# Altium schematic sheet MCU - Motor Control.SchDoc
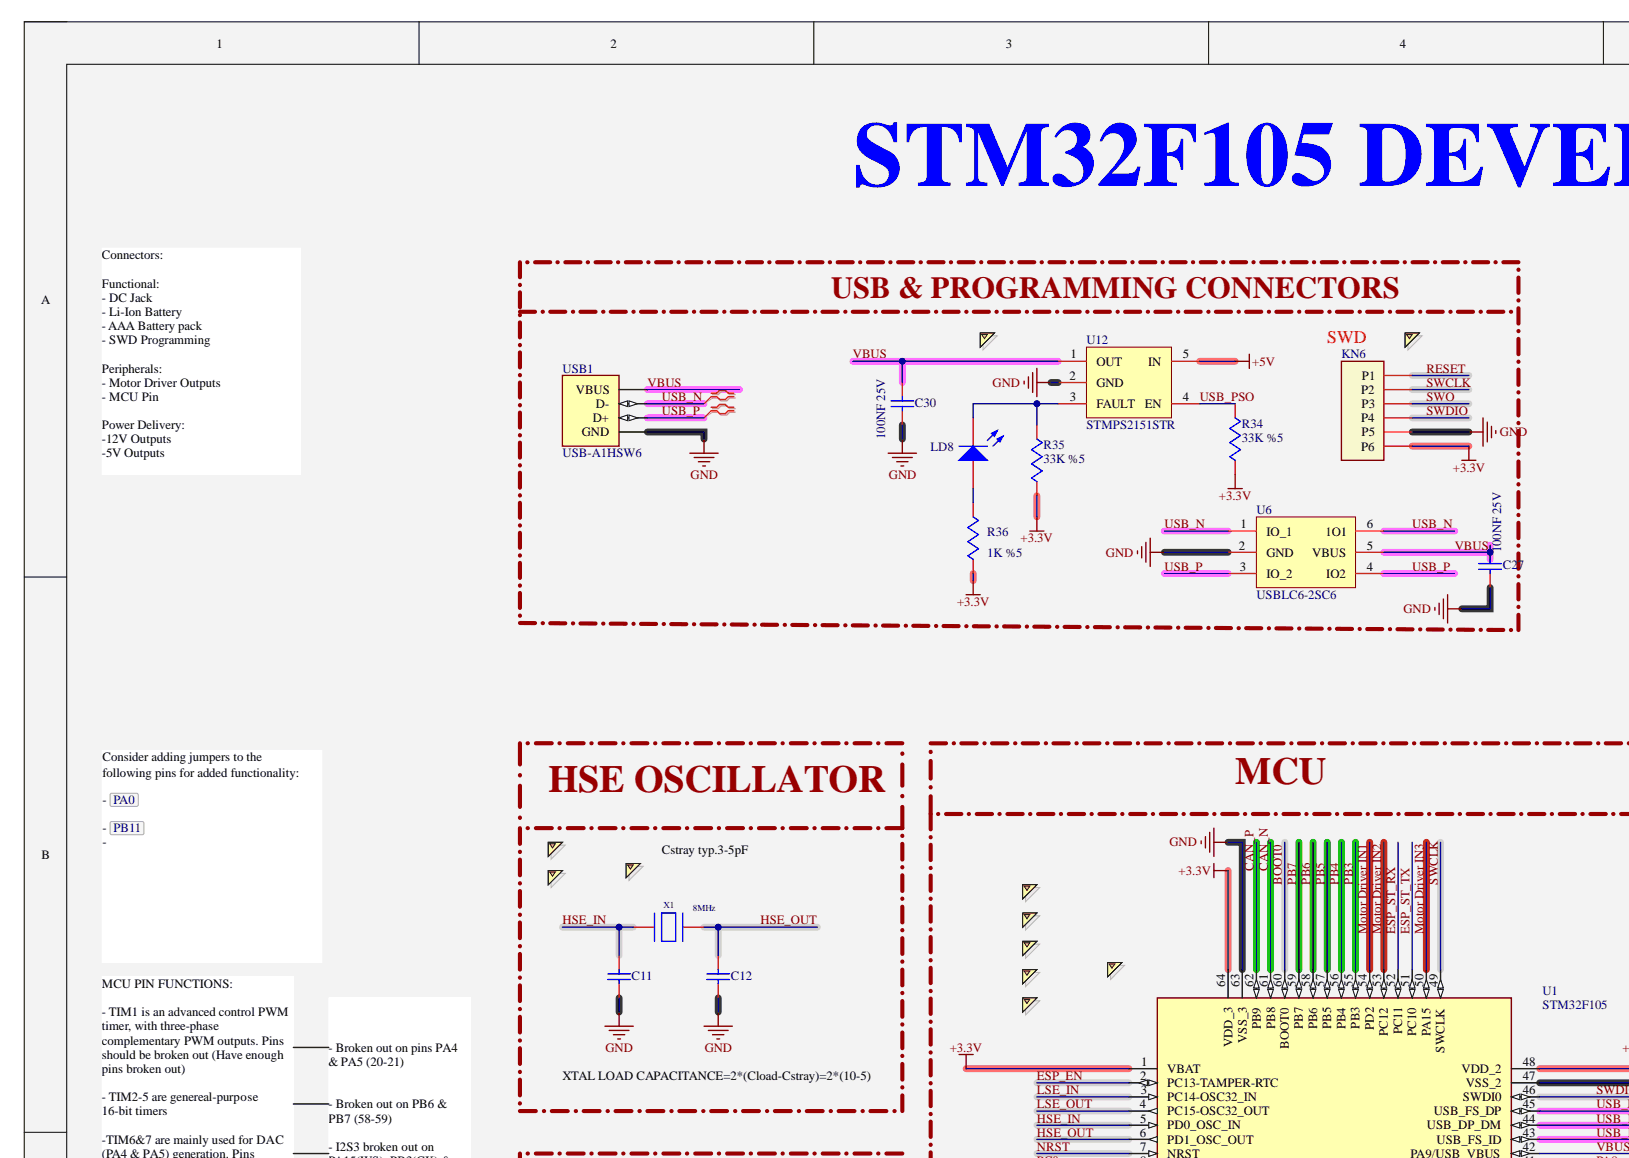
[diagram p0: MCU - Motor Control.SchDoc, top left]
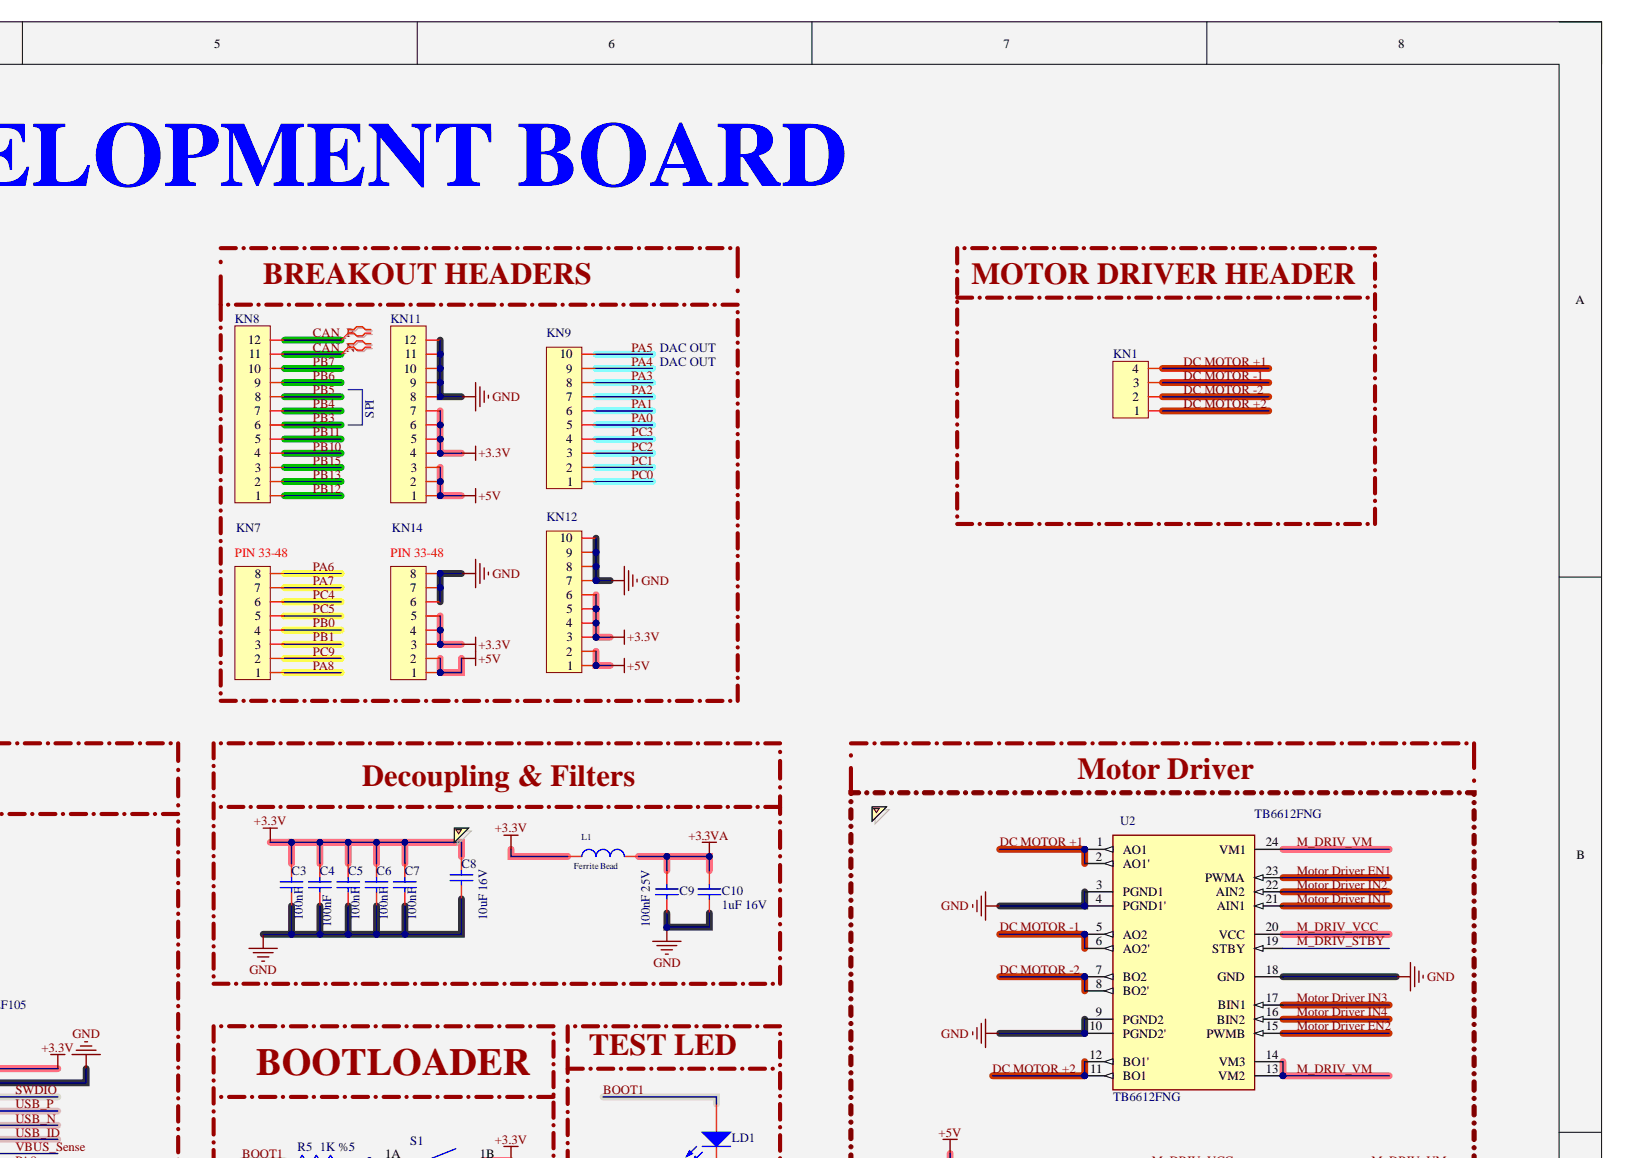
[diagram p1: MCU - Motor Control.SchDoc, top right]
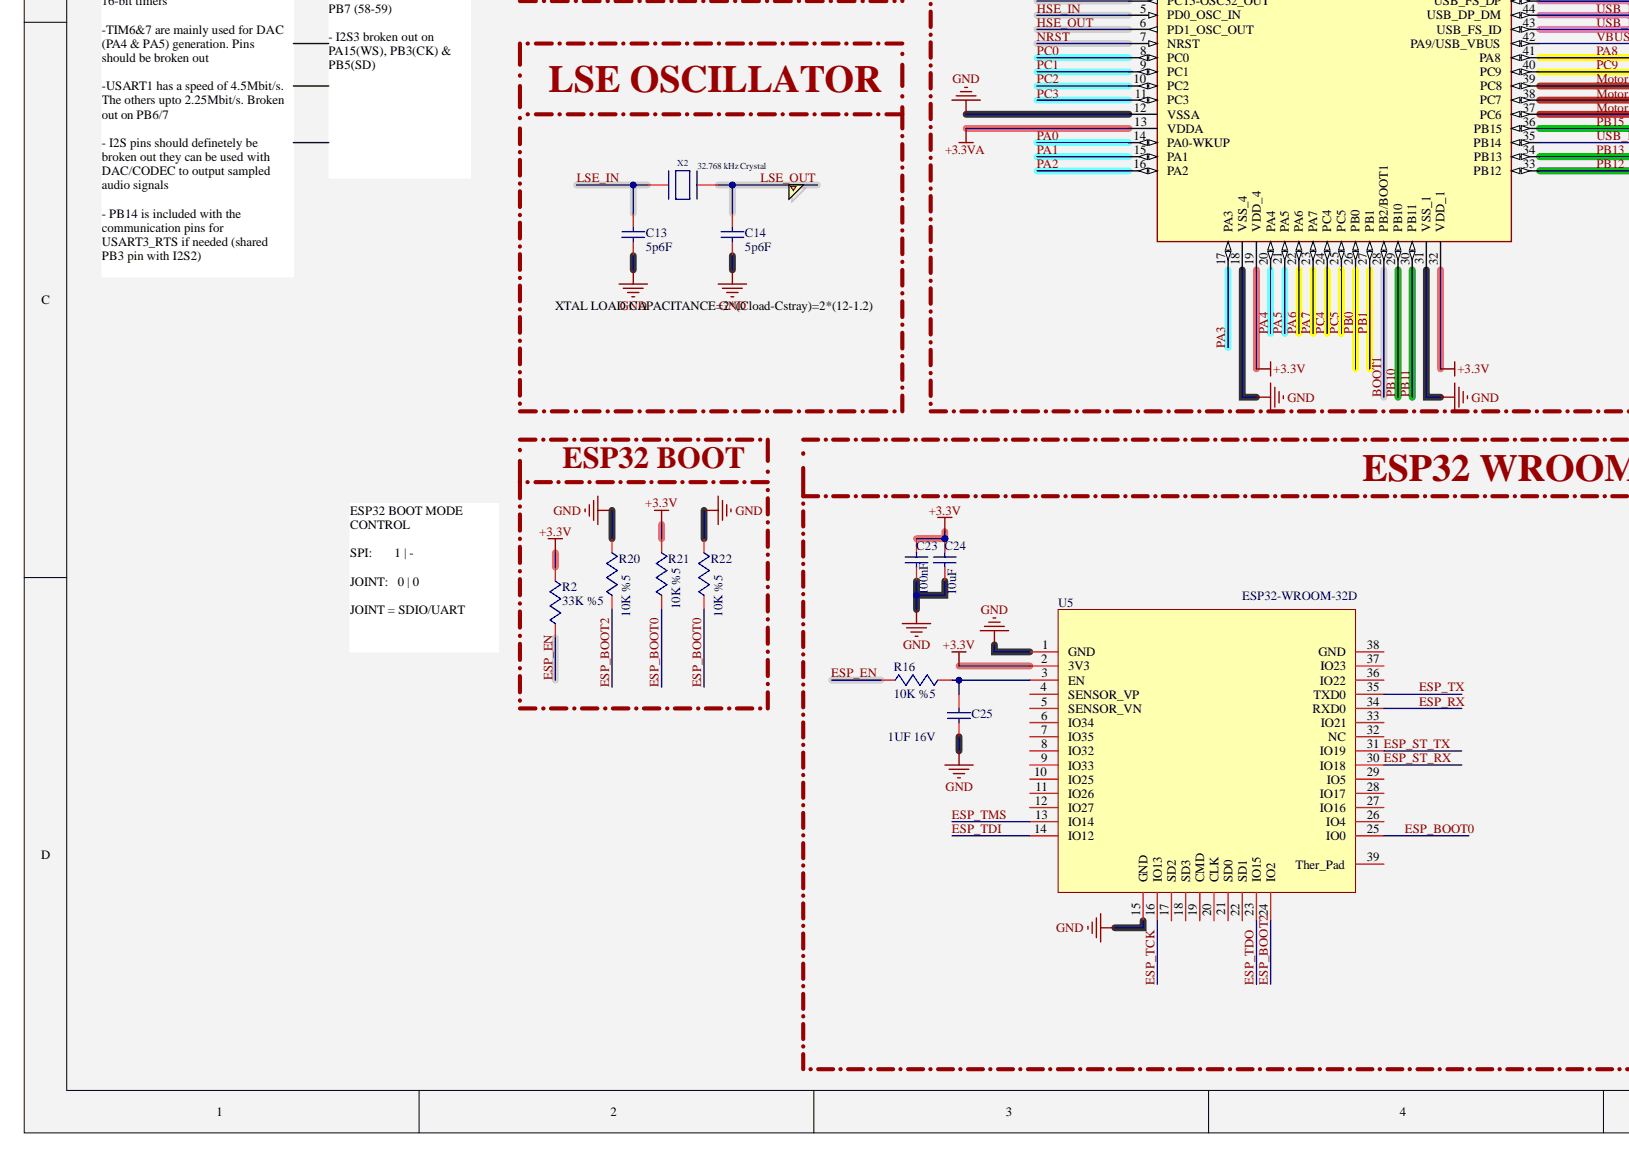
[diagram p2: MCU - Motor Control.SchDoc, bottom left]
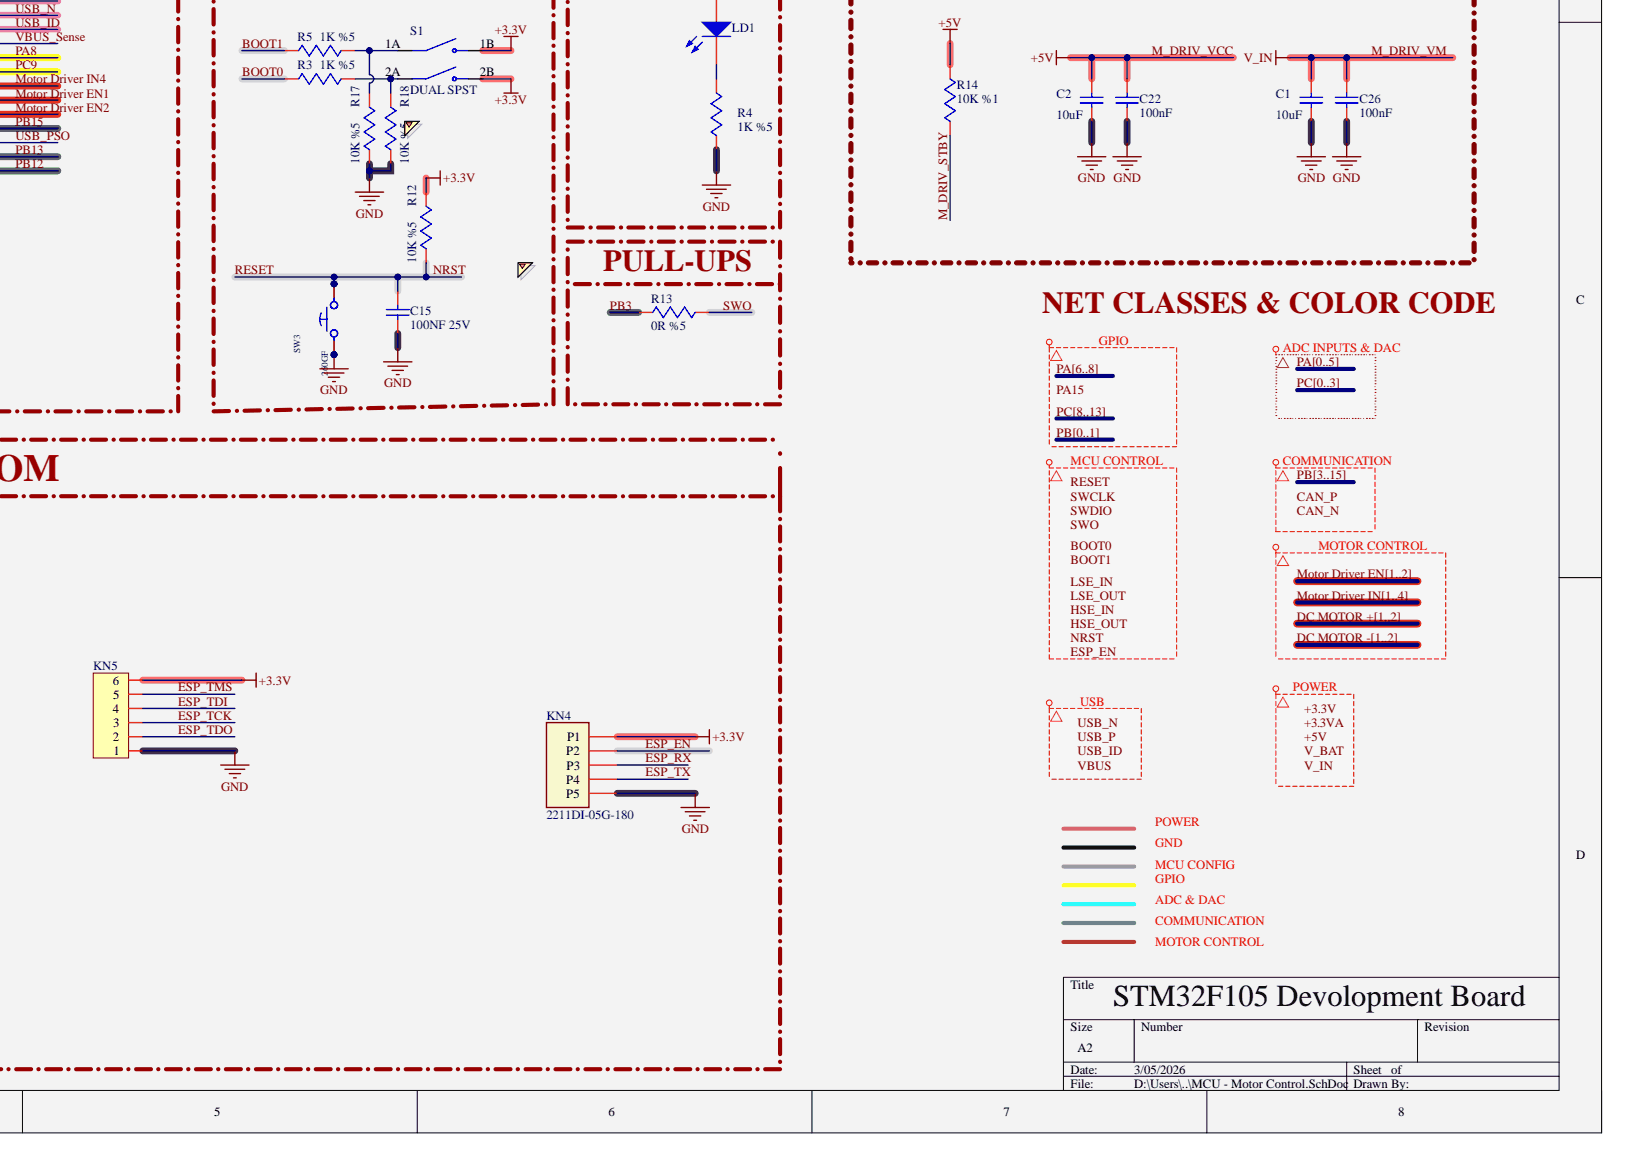
[diagram p3: MCU - Motor Control.SchDoc, bottom right]

## Parts
C1  10uF  [C0805]
C2  10uF  [C0805]
C3  100nF  [C0603]
C4  100nF  [C0603]
C5  100nF  [C0603]
C6  100nF  [C0603]
C7  100nF  [C0603]
C8  10uF 16V  [C0805]
C9  100nF 25V  [C0603]
C10  1uF 16V  [C0603]
C11  =Value  [C0603]
C12  =Value  [C0603]
C13  5p6F  [C0603]
C14  5p6F  [C0603]
C15  100NF 25V  [C0603]
C22  100nF  [C0805]
C23  100nF  [C0603]
C24  10uF  [C0805]
C25  1UF 16V  [C0603]
C26  100nF  [C0805]
C27  100NF 25V  [C0603]
C30  100NF 25V  [C0603]
KN1  4 Pin 2.54mm Header  [4 PIN MOTOR DRIVER]  (value not drawn)
KN4  2211DI-05G-180  [87937_5]
KN5  6 PIN 2.54mm Header  [6 PIN ESP32 SPI CONNECTOR]  (value not drawn)
KN6  6 Pin 2.54mm Header  [Programming Connector]  (value not drawn)
KN7  8 Pin 2.54mm Header  [PIN 33-48]  (value not drawn)
KN8  ADC INPUT PINS + DAC  [ADC_DAC CONNECTOR]  (value not drawn)
KN9  10 PIN 2.54mm HEADER  [10 PIN HEADER]  (value not drawn)
KN11  ADC INPUT PINS + DAC  [ADC_DAC CONNECTOR]  (value not drawn)
KN12  10 PIN 2.54mm HEADER  [10 PIN HEADER]  (value not drawn)
KN14  8 Pin 2.54mm Header  [PIN 33-48]  (value not drawn)
L1  Ferrite Bead  [L0603]
LD1  17-21/R6C-AP1Q2L/3T RED  [LED0805 RED]  (value not drawn)
LD8  19-21/R7C-AN2Q1B/3T  [LED0603 RED]  (value not drawn)
R2  33K %5  [R0603]
R3  1K %5  [R0603]
R4  1K %5  [R0603]
R5  1K %5  [R0603]
R12  10K %5  [R0603]
R13  0R %5  [R0603]
R14  10K %1  [R0603]
R16  10K %5  [R0805]
R17  10K %5  [R0603]
R18  10K %5  [R0603]
R20  10K %5  [R0603]
R21  10K %5  [R0603]
R22  10K %5  [R0603]
R34  33K %5  [R0603]
R35  33K %5  [R0603]
R36  1K %5  [R0603]
S1  DUAL SPST  [SW_DS04-254-2L-02BK]
SW3  260GF  [DTSL61R]
U1  STM32F105  [QFP50P1200X1200X160-64N]
U2  TB6612FNG  [SSOP24]
U5  ESP32-WROOM-32D  [ESPWROOM32D]
U6  USBLC6-2SC6  [SOT23 6_2]
U12  STMPS2151STR  [SOT23 5]
USB1  USB-A1HSW6  [OST_USB-A1HSW6]
X1  8MHz  [HC49U]
X2  32.768 kHz Crystal  [MTF32]

## Nets
GND: C1.1 C2.1 C3.1 C4.1 C5.1 C6.1 C7.1 C8.1 C9.1 C10.1 C11.2 C12.2 C13.1 C14.1 C15.1 C22.1 C23.1 C24.1 C25.1 C26.1 C27.1 C30.1 KN4.5(P5) KN5.1 KN6.5(P5) KN11.8 KN11.9 KN11.10 KN11.11 KN11.12 KN12.7 KN12.8 KN12.9 KN12.10 KN14.6 KN14.7 KN14.8 R4.1 R17.1 R18.1 R20.2 R22.2 SW3.1 SW3.3 U1.12(VSSA) U1.18(VSS_4) U1.31(VSS_1) U1.47(VSS_2) U1.63(VSS_3) U2.3(PGND1) U2.4(PGND1') U2.9(PGND2) U2.10(PGND2') U2.18(GND) U5.1(GND) U5.15(GND) U6.2(GND) U12.2(GND) USB1.4(GND)
+3.3V: C3.2 C4.2 C5.2 C6.2 C7.2 C8.2 C23.2 C24.2 KN4.1(P1) KN5.6 KN6.6(P6) KN11.4 KN11.5 KN11.6 KN11.7 KN12.3 KN12.4 KN12.5 KN12.6 KN14.3 KN14.4 KN14.5 L1.1 R2.2 R12.2 R21.2 R34.1 R35.1 R36.1 S1.1B S1.2B U1.1(VBAT) U1.19(VDD_4) U1.32(VDD_1) U1.48(VDD_2) U1.64(VDD_3) U5.2(3V3)
+3.3VA: C9.2 C10.2 L1.2 U1.13(VDDA)
+5V: C2.2 C22.2 KN11.1 KN11.2 KN11.3 KN12.1 KN12.2 KN14.1 KN14.2 R14.2 U2.20(VCC) U12.5(IN)
BOOT0: R3.1 U1.60(BOOT0)
BOOT1: LD1.2 R5.1 U1.28(PB2/BOOT1)
CAN_N: KN8.11 U1.61(PB8)
CAN_P: KN8.12 U1.62(PB9)
DC MOTOR +1: KN1.4 U2.1(AO1) U2.2(AO1')  [also labelled DC MOTOR +]
DC MOTOR +2: KN1.1 U2.11(BO1) U2.12(BO1')  [also labelled DC MOTOR +]
DC MOTOR -1: KN1.3 U2.5(AO2) U2.6(AO2')  [also labelled DC MOTOR -]
DC MOTOR -2: KN1.2 U2.7(BO2) U2.8(BO2')  [also labelled DC MOTOR -]
ESP_BOOT0: R21.1 R22.1 U5.25(IO0)
ESP_BOOT2: R20.1 U5.24(IO2)
ESP_EN: KN4.2(P2) R2.1 R16.2 U1.2(PC13-TAMPER-RTC)
ESP_RX: KN4.3(P3) U5.34(RXD0)
ESP_ST_RX: U1.52(PC11) U5.30(IO18)
ESP_ST_TX: U1.51(PC10) U5.31(IO19)
ESP_TCK: KN5.3 U5.16(IO13)
ESP_TDI: KN5.4 U5.14(IO12)
ESP_TDO: KN5.2 U5.23(IO15)
ESP_TMS: KN5.5 U5.13(IO14)
ESP_TX: KN4.4(P4) U5.35(TXD0)
HSE_IN: C11.1 U1.5(PD0_OSC_IN) X1.1
HSE_OUT: C12.1 U1.6(PD1_OSC_OUT) X1.2
LSE_IN: C13.2 U1.3(PC14-OSC32_IN) X2.1
LSE_OUT: C14.2 U1.4(PC15-OSC32_OUT) X2.2
M_DRIV_STBY: R14.1 U2.19(STBY)
Motor Driver EN1: U1.38(PC7) U2.23(PWMA)  [also labelled Motor Driver EN]
Motor Driver EN2: U1.37(PC6) U2.15(PWMB)  [also labelled Motor Driver EN]
Motor Driver IN1: U1.54(PD2) U2.21(AIN1)  [also labelled Motor Driver IN]
Motor Driver IN2: U1.53(PC12) U2.22(AIN2)  [also labelled Motor Driver IN]
Motor Driver IN3: U1.50(PA15) U2.17(BIN1)  [also labelled Motor Driver IN]
Motor Driver IN4: U1.39(PC8) U2.16(BIN2)  [also labelled Motor Driver IN]
NRST: C15.2 KN6.1(P1) R12.1 SW3.2 SW3.4 U1.7(NRST)
PA0: KN9.5 U1.14(PA0-WKUP)  [also labelled PA]
PA1: KN9.6 U1.15(PA1)  [also labelled PA]
PA2: KN9.7 U1.16(PA2)  [also labelled PA]
PA3: KN9.8 U1.17(PA3)  [also labelled PA]
PA4: KN9.9 U1.20(PA4)  [also labelled PA]
PA5: KN9.10 U1.21(PA5)  [also labelled PA]
PA6: KN7.8 U1.22(PA6)  [also labelled PA]
PA7: KN7.7 U1.23(PA7)  [also labelled PA]
PA8: KN7.1 U1.41(PA8)  [also labelled PA]
PB0: KN7.4 U1.26(PB0)  [also labelled PB]
PB1: KN7.3 U1.27(PB1)  [also labelled PB]
PB3: KN8.6 R13.1 U1.55(PB3)  [also labelled PB]
PB4: KN8.7 U1.56(PB4)  [also labelled PB]
PB5: KN8.8 U1.57(PB5)  [also labelled PB]
PB6: KN8.9 U1.58(PB6)  [also labelled PB]
PB7: KN8.10 U1.59(PB7)  [also labelled PB]
PB10: KN8.4 U1.29(PB10)  [also labelled PB]
PB11: KN8.5 U1.30(PB11)  [also labelled PB]
PB12: KN8.1 U1.33(PB12)  [also labelled PB]
PB13: KN8.2 U1.34(PB13)  [also labelled PB]
PB15: KN8.3 U1.36(PB15)  [also labelled PB]
PC0: KN9.1 U1.8(PC0)  [also labelled PC]
PC1: KN9.2 U1.9(PC1)  [also labelled PC]
PC2: KN9.3 U1.10(PC2)  [also labelled PC]
PC3: KN9.4 U1.11(PC3)  [also labelled PC]
PC4: KN7.6 U1.24(PC4)
PC5: KN7.5 U1.25(PC5)
PC9: KN7.2 U1.40(PC9)  [also labelled PC]
SWCLK: KN6.2(P2) U1.49(SWCLK)
SWDIO: KN6.4(P4) U1.46(SWDI0)
SWO: KN6.3(P3) R13.2
USB_ID: U1.43(USB_FS_ID)
USB_N: U1.44(USB_DP_DM) U6.1(IO_1) U6.6(1O1) USB1.2(D-)
USB_P: U1.45(USB_FS_DP) U6.3(IO_2) U6.4(IO2) USB1.3(D+)
USB_PSO: R34.2 U1.35(PB14) U12.4(EN)
V_IN: C1.2 C26.2 U2.13(VM2) U2.14(VM3) U2.24(VM1)
VBUS: C27.2 C30.2 U6.5(VBUS) U12.1(OUT) USB1.1(VBUS)
VBUS_Sense: U1.42(PA9/USB_VBUS)
NetC25_2: C25.2 R16.1 U5.3(EN)
NetLD1_1: LD1.1 R4.2
NetLD8_1: LD8.1 R35.2 U12.3(FAULT)
NetLD8_2: LD8.2 R36.2
NetR3_2: R3.2 R18.2 S1.2A
NetR5_2: R5.2 R17.2 S1.1A
PA15:
PA[0..5]:  [also labelled PA]
PA[6..8]:  [also labelled PA]
PB8:  [also labelled PB]
PB9:  [also labelled PB]
PB14:  [also labelled PB]
PB[0..1]:  [also labelled PB]
PB[3..15]:  [also labelled PB]
PC8:  [also labelled PC]
PC10:  [also labelled PC]
PC11:  [also labelled PC]
PC12:  [also labelled PC]
PC13:  [also labelled PC]
PC[0..3]:  [also labelled PC]
PC[8..13]:  [also labelled PC]
V_BAT:

Not connected: U5 4(SENSOR_VP) 5(SENSOR_VN) 6(IO34) 7(IO35) 8(IO32) 9(IO33) 10(IO25) 11(IO26) 12(IO27) 17(SD2) 18(SD3) 19(CMD) 20(CLK) 21(SD0) 22(SD1) 26(IO4) 27(IO16) 28(IO17) 29(IO5) 32(NC) 33(IO21) 36(IO22) 37(IO23) 38(GND) 39(Ther_Pad)

## Library data of the parts
C1 C2 C22 C26 = C0805 1UF 16V %10 X7R: Database Table Name: Capacitor SMD; Malzeme Tanımı: CAP 0805 CER 1u 16V%10 X7R; SapStokKodu: 1200920233; Siparis Kodu: CS2012X7R105K160NRE; ClassName: Motor_Driver; Component Kind: Standard; Part Number: C0805 1UF 16V %10 X7R; Library Name: OTL.DbLib
C3-C7 C9 C23 C27 = C0603 100NF 25V %10 X7R: Database Table Name: Capacitor SMD; Malzeme Tanımı: CAP 0603 CER 100n 25V%10 X7R; SapStokKodu: 1200920254; Siparis Kodu: 06033C104KAT2A; Component Kind: Standard; Part Number: C0603 100NF 25V %10 X7R; Library Name: OTL.DbLib
  C3-C7 C9: ClassName: MCU
  C23: ClassName: ESP32
  C27: Value: 100NF 25V
C8 C24 = C0805 10UF 16V %10 X7R: Database Table Name: Capacitor SMD; Defined by: MK; Malzeme Tanımı: CAP 0805 CER 10UF 16V %10 X7R; SapStokKodu: 1200920687; Siparis Kodu: CC0805KKX7R7BB106; Component Kind: Standard; Part Number: C0805 10UF 16V %10 X7R; Library Name: OTL.DbLib
  C8: ClassName: MCU
  C24: ClassName: ESP32
C10 C25 = C0603 1UF 16V %10 X7R: Database Table Name: Capacitor SMD; Malzeme Tanımı: CAP 0603 CER 1u 16V%10 X7R; SapStokKodu: 1200920367; Siparis Kodu: 0603YC105KAT2A; Component Kind: Standard; Part Number: C0603 1UF 16V %10 X7R; Library Name: OTL.DbLib
  C10: ClassName: MCU
  C25: ClassName: ESP32; Value: 1UF 16V
C11 C12 = C0603 8PF 50V %10: Database Table Name: Capacitor SMD; Malzeme Tanımı: CAP 0603 CER 8pF 50V %10 COG; SapStokKodu: 1200920607; Siparis Kodu: CC0603DRNPO9BN8R0; ClassName: MCU; Component Kind: Standard; Part Number: C0603 8PF 50V %10; Library Name: OTL.DbLib
C13 C14 = C0603 15PF 25V %10 COG: Database Table Name: Capacitor SMD; Malzeme Tanımı: CAP 0603 CER 15p 25V%10 COG; SapStokKodu: 1200920269; Siparis Kodu: 06033A150KAT2A; ClassName: MCU; Component Kind: Standard; Part Number: C0603 15PF 25V %10 COG; Library Name: OTL.DbLib
C15 C30 = C0603 100NF 25V %10 X7R: Database Table Name: Capacitor SMD; Malzeme Tanımı: CAP 0603 CER 100n 25V%10 X7R; SapStokKodu: 1200920254; Siparis Kodu: 0603B104K250CT; Component Kind: Standard; Part Number: C0603 100NF 25V %10 X7R; Value: 100NF 25V; Library Name: OTL.DbLib
KN1 = MOTOR DRIVER: ClassName: Motor_Driver; Component Kind: Standard; Library Name: Custom Library.SchLib
KN4 = 2211DI-05G-180: Database Table Name: Connector; Component Kind: Standard; Part Number: 2211DI-05G-180; Value: 2211DI-05G-180; Library Name: OTL.DbLib
KN5 = 6 PIN ESP32 SPI: ClassName: ESP32; Component Kind: Standard; Library Name: Custom Library.SchLib
KN6 = 191906: Database Table Name: Connector; Defined by: MK; Malzeme Tanımı: KONN 2.50mm 6’LI KABLO 7cm; SAPStokKodu: 1200951442; Siparis Kodu: 302-401006; Value: 191906; Component Kind: Standard; Part Number: 191906; Library Name: OTL.DbLib
KN7 KN14 = PIN 33-48: Component Kind: Standard; Library Name: Connector Headers.SchLib
KN8 KN11 = ADC: Component Kind: Standard; Library Name: Connector Headers.SchLib
KN9 KN12 = 10 PIN HEADER: Component Kind: Standard; Library Name: Custom Library.SchLib
L1 = L0603 33NH 0A3: Database Table Name: Inductor; ClassName: MCU; Component Kind: Standard; Part Number: L0603 33NH 0A3; Library Name: OTL.DbLib
LD1 = 17-21/R6C-AP1Q2L/3T RED: Database Table Name: Diode Led; Malzeme Tanımı: LED 0805 RED 45-112mcd 632nm AlInGaP; SAPStokKodu: 1200960277; Siparis Kodu: 17-21/R6C-AP1Q2L/TR8(ARC); Component Kind: Standard; Part Number: 17-21/R6C-AP1Q2L/3T RED; Value: 17-21/R6C-AP1Q2L/3T RED; Library Name: OTL.DbLib
LD8 = 19-21/R7C-AN2Q1B/3T: Database Table Name: Diode Led; Malzeme Tanımı: LED 0603 RED 90-112mcd 625-645nm; SAPStokKodu: 1200960141; Siparis Kodu: LTST-C190KRKT-AK; ClassName: Status_Led; Component Kind: Standard; Part Number: 19-21/R7C-AN2Q1B/3T; Value: 19-21/R7C-AN2Q1B/3T; Library Name: OTL.DbLib
R2 R34 R35 = R0603 33K %5 1/10W: Database Table Name: Resistor SMD; Malzeme Tanımı: RES 0603 33K %5 1/10W; SapStokKodu: 1200970569; Siparis Kodu: RC1608J333CS; Component Kind: Standard; Part Number: R0603 33K %5 1/10W; Value: 33K %5; Library Name: OTL.DbLib
R3-R5 R36 = R0603 1K %5 1/10W: Database Table Name: Resistor SMD; Malzeme Tanımı: RES 0603 1K %5 1/10W; SapStokKodu: 1200970522; Siparis Kodu: FCF03JT102; Component Kind: Standard; Part Number: R0603 1K %5 1/10W; Value: 1K %5; Library Name: OTL.DbLib
  R3 R5: ClassName: MCU_Control
R12 R17 R18 R20-R22 = R0603 10K %5 1/10W: Database Table Name: Resistor SMD; Malzeme Tanımı: RES 0603 10K %5 1/10W; SapStokKodu: 1200970518; Siparis Kodu: MCR03EZPJ103; Component Kind: Standard; Part Number: R0603 10K %5 1/10W; Value: 10K %5; Library Name: OTL.DbLib
  R12 R17 R18: ClassName: MCU_Control
  R20-R22: ClassName: ESP32
R13 = R0603 0R %5 1/10W: Database Table Name: Resistor SMD; Malzeme Tanımı: RES 0603 0R %5 1/10W; SapStokKodu: 1200970528; Siparis Kodu: MCR03EZPJ000; Component Kind: Standard; Part Number: R0603 0R %5 1/10W; Value: 0R %5; Library Name: OTL.DbLib
R14 = R0603 10K %1 1/10W: Database Table Name: Resistor SMD; Malzeme Tanımı: RES 0603 10K %1 1/10W; SapStokKodu: 1200970746; Siparis Kodu: ACR0603T1002F(10K); Component Kind: Standard; Part Number: R0603 10K %1 1/10W; Value: 10K %1; Library Name: OTL.DbLib
R16 = R0805 10K %5 1/8W: Database Table Name: Resistor SMD; Malzeme Tanımı: RES 0805 10K %5 1/8W; SapStokKodu: 1200970124; Siparis Kodu: WR08X103 JTL; ClassName: ESP32; Component Kind: Standard; Part Number: R0805 10K %5 1/8W; Value: 10K %5; Library Name: OTL.DbLib
S1 = DS04-254-2L-02BK: 2 Position, Through Hole, 2.54 mm Pitch, Flat Slide Actuator, Flat Bottom, Long Pin, DIP Switch; MF: Same Sky; Check_prices: https://www.snapeda.com/parts/DS04-254-2L-02BK/Same+Sky/view-part/?ref=eda; ClassName: MCU_Control; Component Kind: Standard; MP: DS04-254-2L-02BK; Package: None; SnapEDA_Link: https://www.snapeda.com/parts/DS04-254-2L-02BK/Same+Sky/view-part/?ref=snap; Library Name: DPDT-DS04-254-2L-02BK.IntLib
SW3 = DTSL61R: Database Table Name: Misc; Malzeme Tanımı: ANAH. SM TACT VERT 260GF 6x6x2.5mm; SAPStokKodu: 1200910021; Siparis Kodu: DTSL61-R-V-T/R; ClassName: MCU_Control; Component Kind: Standard; Part Number: DTSL61R; Value: 260GF; Library Name: OTL.DbLib
U1 = STM32F103R8T6: ARMÂ® CortexÂ®-M3 STM32F1 Microcontroller IC 32-Bit 72MHz 64KB (64K x 8) FLASH 64-LQFP (10x10); MF: STMicroelectronics; Availability: In Stock; Check_prices: https://www.snapeda.com/parts/STM32F103R8T6/STMicroelectronics/view-part/?ref=eda; ClassName: MCU; Component Kind: Standard; Library Name: STM32F103R8T6.IntLib; MP: STM32F103R8T6; Package: LQFP-64 STMicroelectronics; Price: None; Purchase-URL: https://pricing.snapeda.com/search/part/STM32F103R8T6/?ref=eda; SnapEDA_Link: https://www.snapeda.com/parts/STM32F103R8T6/STMicroelectronics/view-part/?ref=snap
U2 = TB6612FNG: - Motor Driver Power MOSFET Parallel 24-SSOP; MF: Toshiba; Check_prices: https://www.snapeda.com/parts/TB6612FNG/Toshiba/view-part/?ref=eda; ClassName: Motor_Driver; Component Kind: Standard; Library Name: TB6612FNG.IntLib; MP: TB6612FNG; Package: None; SnapEDA_Link: https://www.snapeda.com/parts/TB6612FNG/Toshiba/view-part/?ref=snap
U5 = ESP32-WROOM-32D: Database Table Name: IC Microcontroller; Malzeme Tanımı: IC ESP32 WIFI+BT SMD MODUL; SAPStokKodu: 1200980800; Siparis Kodu: ESP32-WROOM-32D-M113DH6400PH3Q1; Component Kind: Standard; Part Number: ESP32-WROOM-32D; Value: ESP32-WROOM-32D; Library Name: OTL.DbLib
U6 = BS801C: USB ESD PROTECTION IC; Database Table Name: IC Analog; Uretici: Holtek; Value: BS801C; ClassName: USB; Component Kind: Standard; Datasheet Link: https://cdn.ozdisan.com/ETicaret_Dosya/350715_6815717.pdf; Part Number: BS801C; Library Name: OTL.DbLib
U12 = STMPS2151STR: Database Table Name: IC Analog; Defined by: MK; Uretici: STMicroelectronics; Component Kind: Standard; Datasheet Link: https://www.st.com/content/ccc/resource/technical/document/datasheet/e8/d7/48/25/eb/87/45/b3/CD00167470.pdf/files/CD00167470.pdf/jcr:content/translations/en.CD00167470.pdf; Part Number: STMPS2151STR; Value: STMPS2151STR; Library Name: OTL.DbLib
USB1 = USB-A1HSW6: MF: {'manufacturer': u'On Shore Technology Inc.'}; Availability: Unavailable; Component Kind: Standard; Library Name: USB-A1HSW6 (1).IntLib; MP: USB-A1HSW6; Package: None; Price: None
X1 = HC-49U: Database Table Name: Xtal; Malzeme Tanımı: XTAL TH 10MHz LOW PROFILE; SapStokKodu: 1200990008; Siparis Kodu: US0982F; ClassName: MCU; Component Kind: Standard; Part Number: HC-49U; Library Name: OTL.DbLib
X2 = Q_0.032768_MTF32_12.5_20: Database Table Name: Xtal; Malzeme Tanımı: XTAL TH 32.768kHz 12.5pF 20ppm 70C; SapStokKodu: 1200990009; Siparis Kodu: Q0.032768-MTF32-12.5-20-LF; ClassName: MCU; Component Kind: Standard; Part Number: Q_0.032768_MTF32_12.5_20; Library Name: OTL.DbLib
